# Altium schematic sheet SENSOR_Flash_RAM.SchDoc(SENSOR_Flash_RAM)
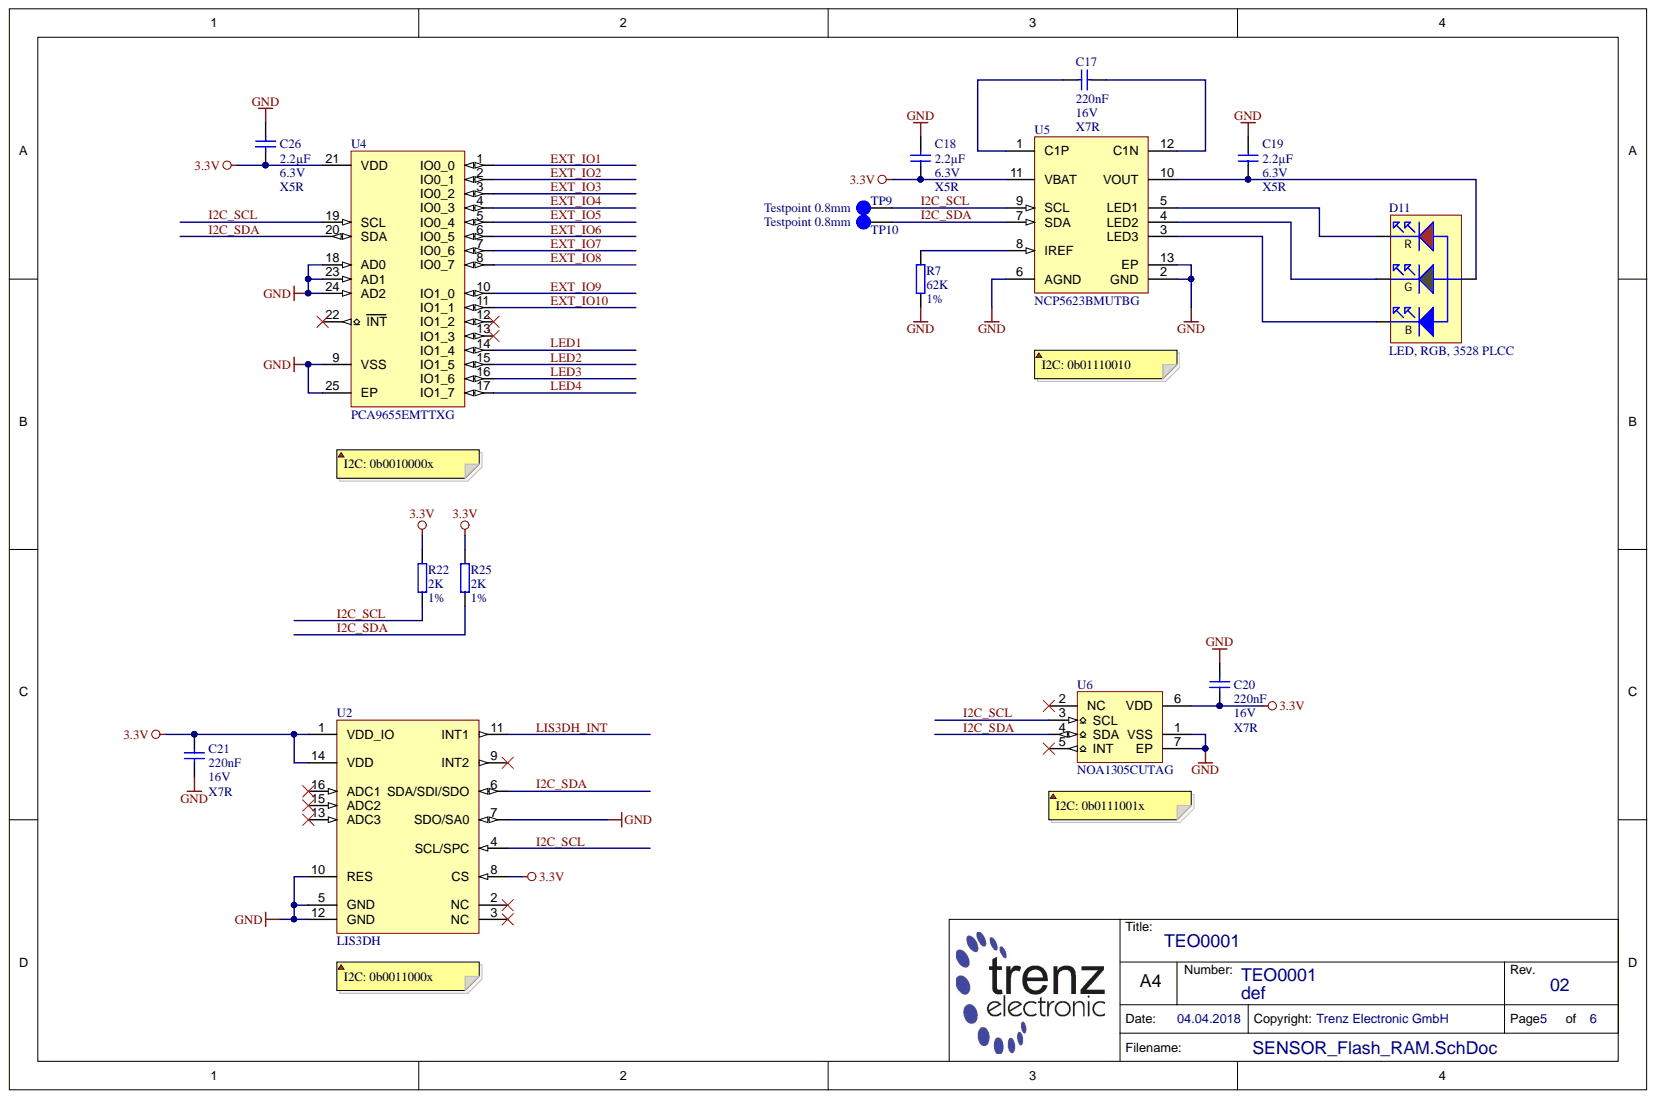
[diagram p0: SENSOR_Flash_RAM.SchDoc]

## Parts
C17  220nF  Voltage: 16V  [CAPC1005X55N]
C18  2.2µF  Technology: X5R  Voltage: 6.3V  [CAPC1005X60N]
C19  2.2µF  Technology: X5R  Voltage: 6.3V  [CAPC1005X60N]
C20  220nF  Technology: X7R  Voltage: 16V  [CAPC1005X55N]
C21  220nF  Technology: X7R  Voltage: 16V  [CAPC1005X55N]
C26  2.2µF  Technology: X5R  Voltage: 6.3V  [CAPC1005X60N]
D11  LED, RGB, 3528 PLCC  [PLCC150P360X280X190-4N-WE]
R7  62K  Tolerance: 1%  [RESC1005X40N]
R22  2K  Tolerance: 1%  [RESC1005X40N]
R25  2K  Tolerance: 1%  [RESC1005X40N]
TP9  Testpoint 0.8mm  [TP_0.8mm]
TP10  Testpoint 0.8mm  [TP_0.8mm]
U2  LIS3DH  [PQFN50P300X300X100-16N]
U4  PCA9655EMTTXG  [QFN50P400X400X80-25T210X210N]
U5  NCP5623BMUTBG  [SON40P200X200X60-13N]
U6  NOA1305CUTAG  [SON65P200X200X65-7T90X160N]

## Nets
3.3V: C18.1 C20.1 C21.2 C26.1 R22.1 R25.1 U2.1(VDD_IO) U2.8(CS) U2.14(VDD) U4.21(VDD) U5.11(VBAT) U6.6(VDD)
GND: C18.2 C19.2 C20.2 C21.1 C26.2 R7.2 U2.5(GND) U2.7(SDO/SA0) U2.10(RES) U2.12(GND) U4.9(VSS) U4.18(AD0) U4.23(AD1) U4.24(AD2) U4.25(EP) U5.2(GND) U5.6(AGND) U5.13(EP) U6.1(VSS) U6.7(EP)
EXT_IO1: U4.1(IO0_0)
EXT_IO2: U4.2(IO0_1)
EXT_IO3: U4.3(IO0_2)
EXT_IO4: U4.4(IO0_3)
EXT_IO5: U4.5(IO0_4)
EXT_IO6: U4.6(IO0_5)
EXT_IO7: U4.7(IO0_6)
EXT_IO8: U4.8(IO0_7)
EXT_IO9: U4.10(IO1_0)
EXT_IO10: U4.11(IO1_1)
I2C_SCL: R22.2 TP9.1 U2.4(SCL/SPC) U4.19(SCL) U5.9(SCL) U6.3(SCL)
I2C_SDA: R25.2 TP10.1 U2.6(SDA/SDI/SDO) U4.20(SDA) U5.7(SDA) U6.4(SDA)
LED1: U4.14(IO1_4)
LED2: U4.15(IO1_5)
LED3: U4.16(IO1_6)
LED4: U4.17(IO1_7)
LIS3DH_INT: U2.11(INT1)
NetC17_1: C17.1 U5.1(C1P)
NetC17_2: C17.2 U5.12(C1N)
NetC19_1: C19.1 D11.1 U5.10(VOUT)
NetD11_2: D11.2 U5.3(LED3)
NetD11_3: D11.3 U5.5(LED1)
NetD11_4: D11.4 U5.4(LED2)
NetR7_1: R7.1 U5.8(IREF)

Not connected: U2 2(NC) 3(NC) 9(INT2) 13(ADC3) 15(ADC2) 16(ADC1); U4 12(IO1_2) 13(IO1_3) 22(INT); U6 2(NC) 5(INT)

## Library data of the parts
C17 C20 C21 = 22768: KON 220nF 16V X7R SMD; Database Table Name: C_1005_0402; Mixed: SMT; Value: 220n; AN: 22768; Case: 1005 (0402); Component Kind: Standard; Library Name: TE.DbLib
  C17: Technology: X7R
C18 C19 C26 = 22749: KON 2,2µF 6,3V X5R SMD; Database Table Name: C_1005_0402; Mixed: SMT; Value: 2.2u; AN: 22749; Case: 1005 (0402); Component Kind: Standard; Library Name: TE.DbLib
D11 = 26741: H-ART: 150141M173100; Mixed: SMT; AN: 26741; Case: 1411 (3528) PLCC; Component Kind: Standard; Database Table Name: LED; Description: LED, RGB, 3528 PLCC; Library Name: TE.DbLib; HelpURL: B:\ad\adlib\datasheet\150141M173100
R7 = 23146: WID SMD 62K 1% 0,063W; Database Table Name: R_1005_0402; Mixed: SMT; Preferred: Yes; AN: 23146; Case: 1005 (0402); Component Kind: Standard; Library Name: TE.DbLib; Technology: TK100; Value: 62K
R22 R25 = 25073: WID SMD 2K 1% 0,063W; Database Table Name: R_1005_0402; Mixed: SMT; AN: 25073; Case: 1005 (0402); Component Kind: Standard; Library Name: TE.DbLib; Technology: TK100; Value: 2K
TP9 TP10 = 26289: Database Table Name: PCB; Mixed: MECH; AN: 26289; Component Kind: Standard; Description: Testpoint 0.8mm; Library Name: TE.DbLib
U2 = 27979: Database Table Name: SENSOR; Mixed: SMT; AN: 27979; Case: LGA16; Component Kind: Standard; Description: LIS3DH; H-ART: LIS3DH; Library Name: TE.DbLib; HelpURL: b:\AD\adlib\datasheet\ST_LIS3DH\
U4 = 28790: Database Table Name: IF; Mixed: SMT; AN: 28790; Case: WQFN24; Component Kind: Standard; Description: PCA9655EMTTXG; H-ART: PCA9655EMTTXG; Library Name: TE.DbLib; HelpURL: b:\AD\adlib\datasheet\PCA9655E\
U5 = 28789: Database Table Name: DRIVER; Mixed: SMT; AN: 28789; Case: LLGA12; Component Kind: Standard; Description: NCP5623BMUTBG; H-ART: NCP5623BMUTBG; Library Name: TE.DbLib; HelpURL: b:\AD\adlib\datasheet\NCP5623B\
U6 = 28787: Database Table Name: SENSOR; Mixed: SMT; AN: 28787; Case: DFN6; Component Kind: Standard; Description: NOA1305CUTAG; H-ART: NOA1305CUTAG; Library Name: TE.DbLib; HelpURL: b:\AD\adlib\datasheet\NOA1305\
